# Altium schematic sheet AUAV_X05_NRF24.SchDoc(AUAV_X05_NRF24)
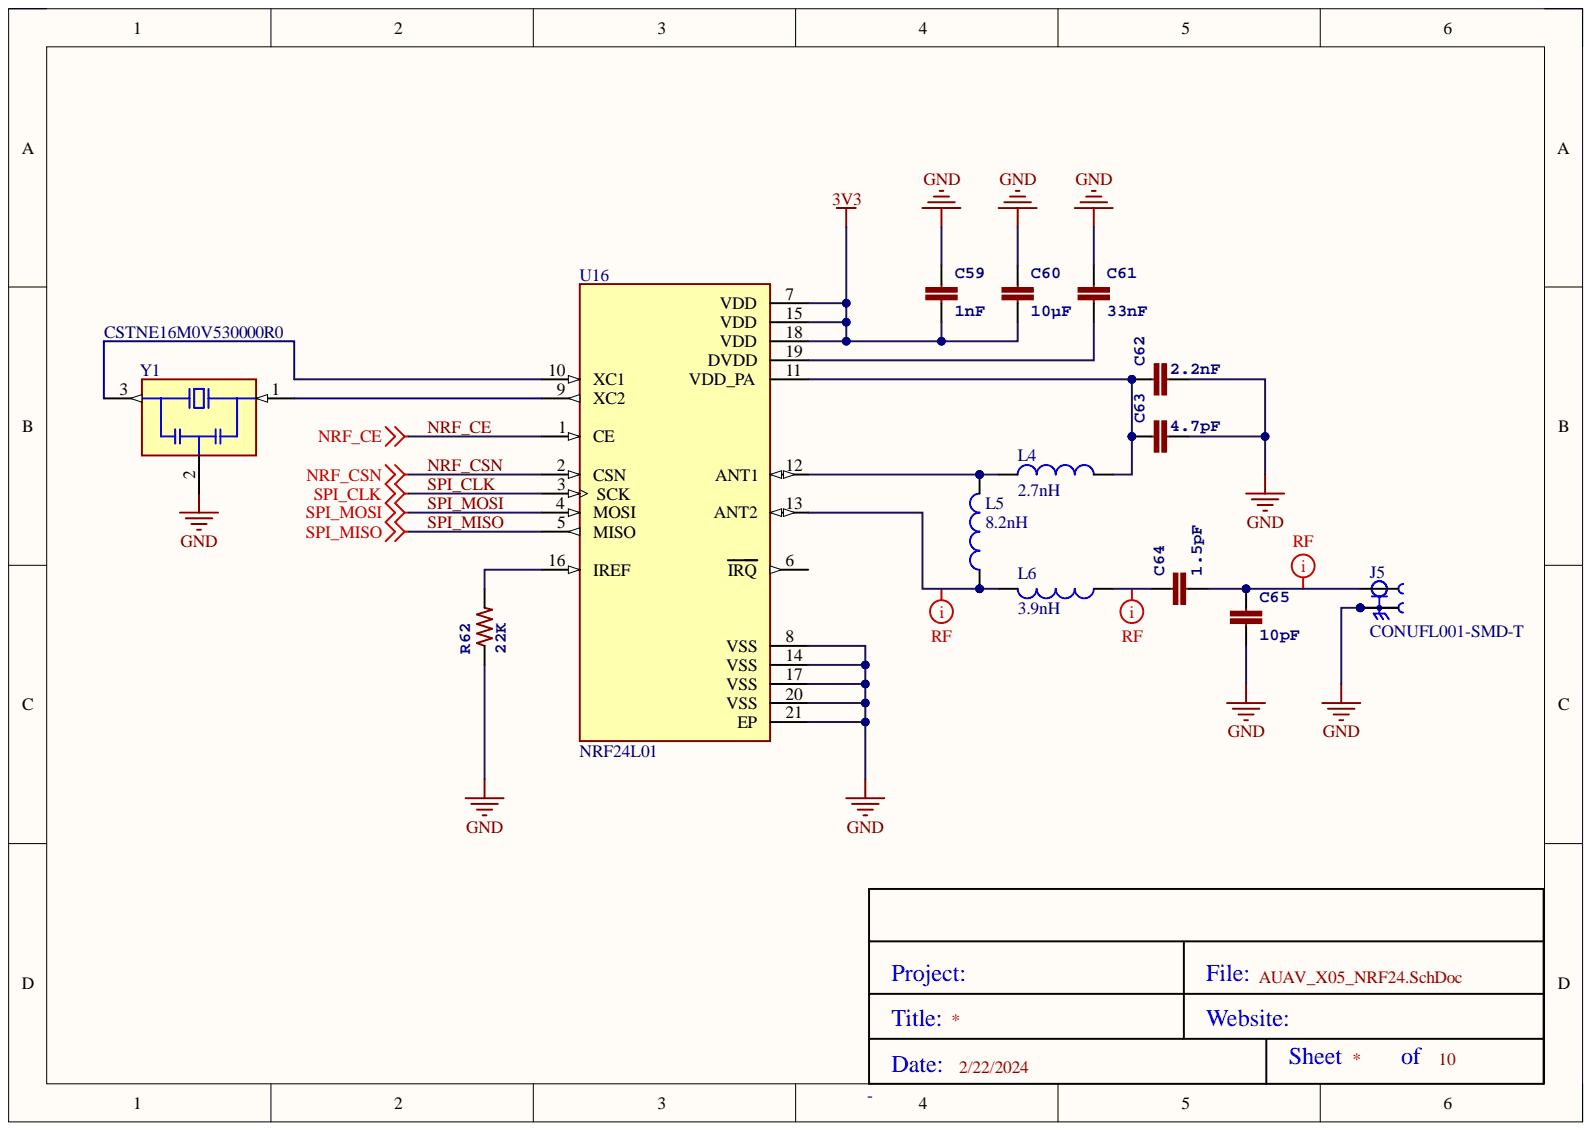
[diagram p0: AUAV_X05_NRF24.SchDoc]

## Parts
*?  Title Block  (value not drawn)
C59  1nF  [0402-CAP]
C60  10µF  [0805-CAP]
C61  33nF  [0402-CAP]
C62  2.2nF  [0402-CAP]
C63  4.7pF  [0402-CAP]
C64  1.5pF  [0402-CAP]
C65  10pF  [0402-CAP]
J5  CONUFL001-SMD-T  [LINX_CONUFL001-SMD-T]  (value not drawn)
L4  2.7nH  [INDC1005X65N]
L5  8.2nH  [INDC1005X65N]
L6  3.9nH  [INDC1005X65N]
R62  22K  [0402-RES]
U16  NRF24L01  [QFN50P400X400X95-21N]
Y1  CSTNE16M0V530000R0  [OSC_CSTNE16M0V530000R0]

## Nets
3V3: C59.P$2 C60.P$2 U16.7(VDD) U16.15(VDD) U16.18(VDD)
GND: C59.P$1 C60.P$1 C61.P$1 C62.P$2 C63.P$2 C65.P$2 J5.2 J5.3 R62.P$1 U16.8(VSS) U16.14(VSS) U16.17(VSS) U16.20(VSS) U16.21(EP) Y1.2
NRF_CE: U16.1(CE)
NRF_CSN: U16.2(CSN)
SPI_CLK: U16.3(SCK)
SPI_MISO: U16.5(MISO)
SPI_MOSI: U16.4(MOSI)
NetC61_P$2: C61.P$2 U16.19(DVDD)
NetC62_P$1: C62.P$1 C63.P$1 L4.2 U16.11(VDD_PA)
NetC64_P$1: C64.P$1 L6.1
NetC64_P$2: C64.P$2 C65.P$1 J5.1
NetL4_1: L4.1 L5.2 U16.12(ANT1)
NetL5_1: L5.1 L6.2 U16.13(ANT2)
NetR62_P$2: R62.P$2 U16.16(IREF)
NetU16_9: U16.9(XC2) Y1.1
NetU16_10: U16.10(XC1) Y1.3

Not connected: U16 6(IRQ)

## Library data of the parts
C59 C61-C65 = CAP0402: CAP CER 8PF 50V C0G 0402; 3DR_PARTNO: ECM0710; PARTNO: C1005C0G1H080C; Value: 8p; Component Kind: Standard; Supplier 1: Digi-Key; Supplier Part Number 1: 445-4890-1-ND; Library Name: AUAV_X05.SchLib
C60 = CAP0805: CAP CER 4.7UF 16V 10% X7R 0805; 3DR_PARTNO: ECM0622; PARTNO: CL21B475KOFNNNE; Value: 4u7; Component Kind: Standard; DATASHEET: http://www.digikey.com/product-detail/en/CL21B475KOFNNNE/1276-2873-2-ND/3888531; Supplier 1: Digi-Key; Supplier Part Number 1: 1276-2873-1-ND; Library Name: AUAV_X05.SchLib
J5 = CONUFL001-SMD-T: MHF1 Straight Surface Mount Jack, Tape and Reel; MF: Linx Technologies; Availability: In Stock; Check_prices: https://www.snapeda.com/parts/CONUFL001-SMD-T/Linx+Technologies+Inc./view-part/?ref=eda; Component Kind: Standard; MP: CONUFL001-SMD-T; Package: None; Price: None; Purchase-URL: https://pricing.snapeda.com/search/part/CONUFL001-SMD-T/?ref=eda; SnapEDA_Link: https://www.snapeda.com/parts/CONUFL001-SMD-T/Linx+Technologies+Inc./view-part/?ref=snap; Library Name: AUAV_X05.SchLib
L4-L6 = IND0402: 39nH Unshielded Multilayer Inductor 200mA 1.3Ohm Max 0402 (1005 Metric); MF: Abracon LLC; MP: AIMC-0402-39NJ-T; Availability: In Stock; Check_prices: https://www.snapeda.com/parts/AIMC-0402-39NJ-T/Abracon%20LLC/view-part/1612276/?ref=eda; Component Kind: Standard; Package: 0402-2 Abracon; Price: None; Purchase-URL: https://pricing.snapeda.com/search/part/AIMC-0402-39NJ-T/?ref=eda; SnapEDA_Link: https://www.snapeda.com/parts/AIMC-0402-39NJ-T/Abracon%20LLC/view-part/1612276/?ref=snap; Library Name: AUAV_X05.SchLib
R62 = RESISTOR0402-RES: RES 10K OHM 1/16W 1% 0402 SMD; 3DR_PARTNO: ECM0800; PARTNO: RC0402FR-0710KL; Component Kind: Standard; Supplier 1: Digi-Key; Supplier Part Number 1: 311-10.0KLRCT-ND; Value: 10K; Library Name: AUAV_X05.SchLib
U16 = NRF24L01: IC RF TxRx Only General ISM > 1GHZ 2.4GHz 20-VFQFN Exposed Pad; MF: Nordic Semiconductor; MP: NRF24L01+; Availability: Unavailable; Component Kind: Standard; Package: QFN-20 Nordic Semiconductor; Price: None; SnapEDA_Link: https://www.snapeda.com/parts/NRF24L01%2B/Nordic%20Semiconductor%20ASA/view-part/1023904/?ref=snap; Library Name: AUAV_X05.SchLib
Y1 = CSTNE16M0V530000R0: 16MHz Ceramic Resonator Built in Capacitor 15pF Â±0.3% 40 Ohms -40Â°C ~ 85Â°C Surface Mount; MF: Murata Electronics; Availability: Warning; Check_prices: https://www.snapeda.com/parts/CSTNE16M0V530000R0/Murata%20Electronics%20North%20America/view-part/2773601/?ref=eda; Component Kind: Standard; MP: CSTNE16M0V530000R0; Package: SMD-3 Murata; Price: None; Purchase-URL: https://pricing.snapeda.com/search/part/CSTNE16M0V530000R0/?ref=eda; SnapEDA_Link: https://www.snapeda.com/parts/CSTNE16M0V530000R0/Murata%20Electronics%20North%20America/view-part/2773601/?ref=snap; Library Name: AUAV_X05.SchLib
*? = Title Block: Component Kind: Standard; Size: -; Library Name: AUAV_X05.SchLib
